# Altium schematic sheet 13-FPGA_Power.SchDoc(13-FPGA_Power)
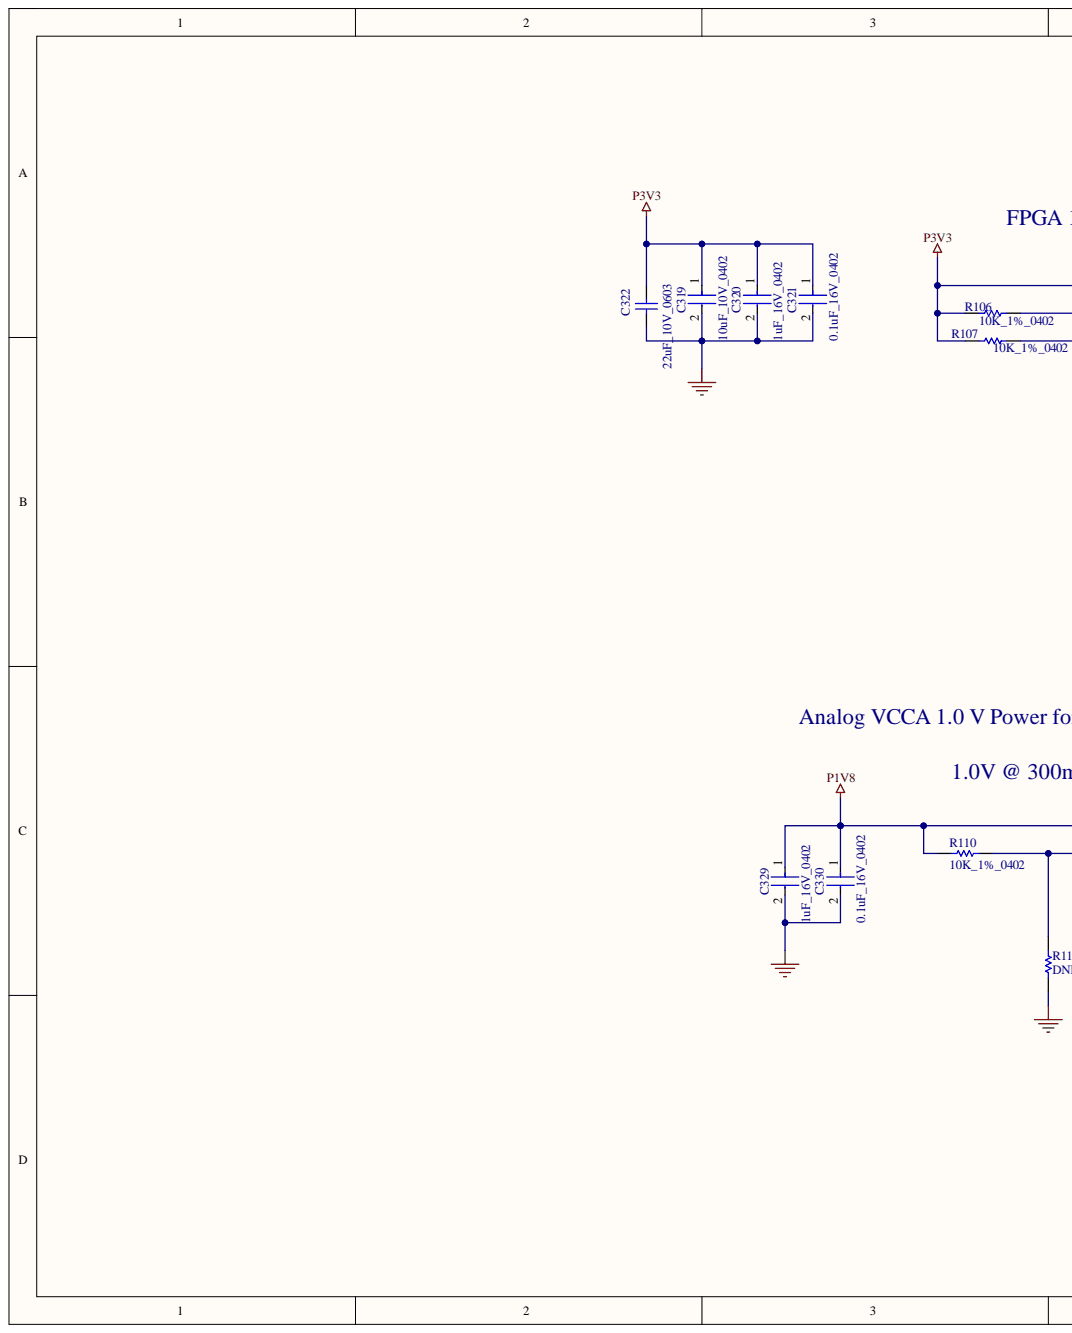
[diagram p0: 13-FPGA_Power.SchDoc, left]
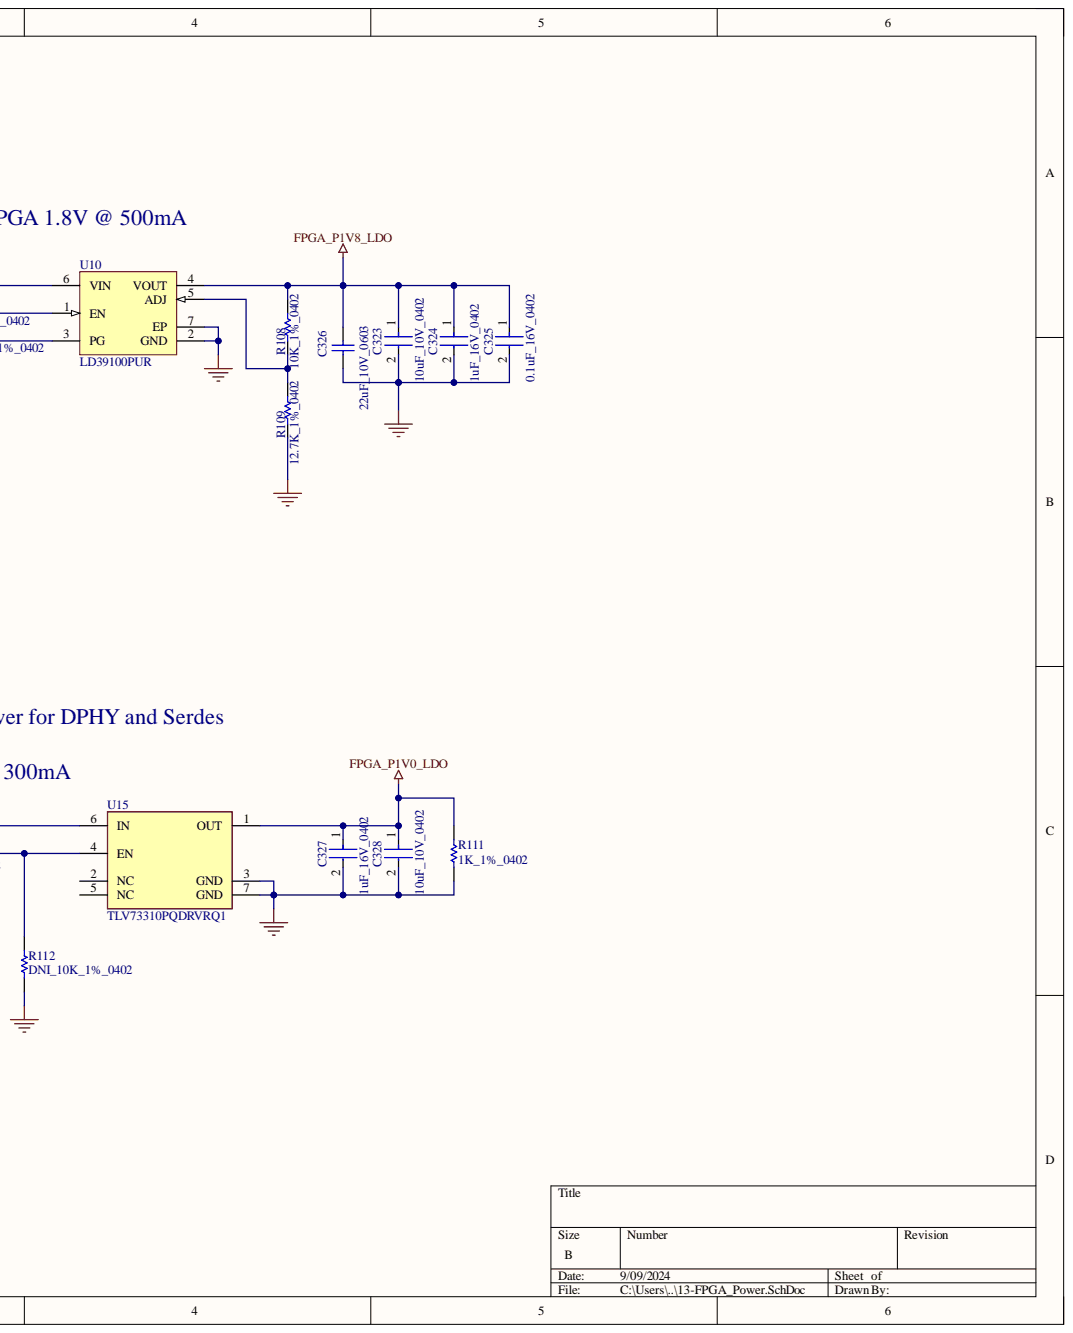
[diagram p1: 13-FPGA_Power.SchDoc, right]

## Parts
C319  10uF_10V_0402  [FP-CL05A106MP5NUNC-MFG]
C320  1uF_16V_0402  [FP-0402-L_1_0_0_05-W_0_5-IPC_A]
C321  0.1uF_16V_0402  [FP-C0402-BB-MFG]
C322  22uF_10V_0603  [CAPC1608X100X20ML10]
C323  10uF_10V_0402  [FP-CL05A106MP5NUNC-MFG]
C324  1uF_16V_0402  [FP-0402-L_1_0_0_05-W_0_5-IPC_A]
C325  0.1uF_16V_0402  [FP-C0402-BB-MFG]
C326  22uF_10V_0603  [CAPC1608X100X20ML10]
C327  1uF_16V_0402  [FP-0402-L_1_0_0_05-W_0_5-IPC_A]
C328  10uF_10V_0402  [FP-CL05A106MP5NUNC-MFG]
C329  1uF_16V_0402  [FP-0402-L_1_0_0_05-W_0_5-IPC_A]
C330  0.1uF_16V_0402  [FP-C0402-BB-MFG]
R106  10K_1%_0402  [RESC1005X40X25NL10T10]
R107  10K_1%_0402  [RESC1005X40X25NL10T10]
R108  10K_1%_0402  [RESC1005X40X25NL10T10]
R109  12.7K_1%_0402  [RESC1005X40X25NL05T05]
R110  10K_1%_0402  [RESC1005X40X25NL10T10]
R111  1K_1%_0402  [RESC1005X40X25LL05T10]
R112  DNI_10K_1%_0402  [RESC1005X40X25NL10T10]  (no BOM)
U10  LD39100PUR  [DFN6D_M]
U15  TLV73310PQDRVRQ1  Output Current: 300mA  [FP-DRV0006D-MFG]

## Nets
FPGA_P1V0_LDO: C327.1 C328.1 R111.2 U15.1(OUT)
FPGA_P1V8_LDO: C323.1 C324.1 C325.1 C326.2 R108.2 U10.4(VOUT)
GND: C319.2 C320.2 C321.2 C322.1 C323.2 C324.2 C325.2 C326.1 C327.2 C328.2 C329.2 C330.2 R109.1 R111.1 R112.1 U10.2(GND) U10.7(EP) U15.3(GND) U15.7(GND)
P1V8: C329.1 C330.1 R110.2 U15.6(IN)
P3V3: C319.1 C320.1 C321.1 C322.2 R106.2 R107.2 U10.6(VIN)
NetR106_1: R106.1 U10.1(EN)
NetR107_1: R107.1 U10.3(PG)
NetR108_1: R108.1 R109.2 U10.5(ADJ)
NetR110_1: R110.1 R112.2 U15.4(EN)

Not connected: U15 2(NC) 5(NC)

## Library data of the parts
C319 C323 C328 = CMP-13271-000002-1: CAP CER 10UF 10V X5R 0402; Manufacturer: Samsung; Manufacturer Part Number: CL05A106MP5NUNC; Material: Ceramic; Temperature Coefficient: 15%; Tolerance: 20%; Automotive: No; Capacitance: 10uF; Category: Cap; Component Kind: Standard; ComponentLink2Description: Datasheet; ComponentLink2URL: http://www.samsungsem.com/kr/support/product-search/mlcc/__icsFiles/afieldfile/2019/05/10/19-CL05A106MP5NUNC.pdf; Device Class L1: Passive Components; Device Class L2: Capacitors; Device Class L3: Ceramic Capacitors; Digikey Description: CAP CER 10UF 10V X5R 0402; Height: 0.7mm; Ipc Land Pattern Name: CAPC10050X50; Lead Free: Yes; Library Name: Altium Content Vault; Octopart: https://octopart.com/cl05a106mp5nunc-samsung-22457395; Package: CL05A106MP5NUNC; RoHS: TRUE; Temperature: -55°C to +85°C; Temperature Characteristic: X5R; Temperature Range High: +85°C; Temperature Range Low: -55°C; Value: 10uF; Voltage Rating: 10V
C320 C324 C327 C329 = CMP-14477-000104-2: None; Capacitance: 1uF; Dielectric: X5R; Tolerance: 10%; Voltage Rating: 16V; Case Code (Imperial): 0402; Case Code (Metric): 1005; Case/Package: 0402; Component Kind: Standard; Construction: Flat; Depth: 0.5mm; Halogen Free: Halogen Free; Height: 0.5mm; Length: 1mm; Library Name: Altium Content Vault; Max Operating Temperature: 85°C; Min Operating Temperature: -55°C; Mount: Surface Mount; Number of Pins: 2; Package Quantity: 1; Packaging: Tape and Reel; Radiation Hardening: No; RoHS Compliant: Yes; Series: M; Termination: SMD/SMT; Thickness: 0.022inch; Voltage Rating (DC): 16V; Width: 0.02inch
C321 C325 C330 = CMP-1034-02068-3: CAP CER 0.1UF 16V 5% X7R 0402; Manufacturer: Kemet; Manufacturer Part Number: C0402C104J4RACTU; Capacitance: 0.10 μF; Material: Ceramic; Temperature Coefficient: 15%; Tolerance: 0.05; Value: 0.10 μF; Automotive: No; Category: Cap; Component Kind: Standard; ComponentLink2Description: Datasheet; ComponentLink2URL: https://content.kemet.com/datasheets/KEM_C1002_X7R_SMD.pdf; Device Class L1: Passive Components; Device Class L2: Capacitors; Device Class L3: Ceramic Capacitors; Digikey Description: CAP CER 0.1UF 16V 5% X7R 0402; Height: 0.55mm; Ipc Land Pattern Name: CAPC10050X50; Lead Free: Yes; Library Name: Altium Content Vault; Mouser Description: CAP CER 0.1UF 16V X7R 0402; Octopart: https://octopart.com/c0402c104j4ractu-kemet-12573893; Package: C0402-BB; RoHS: TRUE; Temperature: -55°C to +125°C; Temperature Characteristic: X7R; Temperature Range High: +125°C; Temperature Range Low: -55°C; Voltage Rating: 16 V
C322 C326 = CMP-2000-05441-1: Chip Capacitor, 22 uF, +/- 20%, 10 V, -55 to 85 degC, 0603 (1608 Metric), RoHS, Tape and Reel; Manufacturer: TDK; PCN Part Number: MLCCPartNumberChange30/Nov/2012; Capacitance: 22µF; Design Resources: 20WNon-IsolatedBuckLEDDriverUsingPLLNK460KG; Kits: 445-9014-KIT-ND-KITMLCC100PF-22UF060310-50V; Online Catalog: CSeries,GeneralPurpose; Other Names: 445-9077-1; Product Training Modules: CSeriesGeneralApplicationsSEAT,CCV,andTVCLDesignToolsGuidetoReplacinganElectrolyticCapacitorwithanMLCC; Temperature Coefficient: X5R; Voltage - Rated: 10V; Applications: GeneralPurpose; Category: Capacitors; Component Kind: Standard; Datasheet URL: http://download.siliconexpert.com/pdfs/2015/4/21/15/54/45/779/tdk_/manual/37024850441143360mlcc_commercial_general_en.pdf; Datasheet Version: Mar-15; Family: CeramicCapacitors; Features: -; Height - Seated (Max): -; Lead Spacing: -; Lead Style: -; Library Name: Altium Content Vault; Manufacturer URL: http://www.tdk.com/; Mounting Type: SurfaceMount,MLCC; Operating Temperature: -55degC~85degC; Package / Case: 0603(1608Metric); Package Description: 2-Pin Surface Mount Device, Body 1.6 x 0.8 mm; Package Reference: 603; Packaging: CutTape(CT); Ratings: -; RoHS Information: RoHSCertificate-MLCC; Series: C; Size / Dimension: 0.063"Lx0.031"W(1.60mmx0.80mm); Standard Package: 1; Thickness (Max): 0.035"(0.90mm); Tolerance: ±20%
R106-R108 R110 R112 = CMP-2002-04694-1: Manufacturer: Bourns; Part Number: CR0402-FX-1002GLF; Library Name: Altium Content Vault
  R106-R108 R110: Component Kind: Standard
R109 = CMP-2002-08182-1: Manufacturer: Vishay Dale; Part Number: CRCW040212K7FKED; Component Kind: Standard; Library Name: Altium Content Vault
R111 = CMP-2002-03045-1: Manufacturer: Vishay Dale; Part Number: CRCW04021K00FKEDHP; Component Kind: Standard; Library Name: Altium Content Vault
U10 = CMP-0244-00287-1: 1 A Low Quiescent Current Low Noise Voltage Regulator, Adjustable from 0.8V, 6-Pin VFDFPN, Tape and Reel; Component Kind: Standard; ComponentLink1Description: Manufacturer URL; ComponentLink1URL: http://www.st.com; ComponentLink2Description: Datasheet; ComponentLink2URL: http://www.st.com/internet/com/TECHNICAL_RESOURCES/TECHNICAL_LITERATURE/DATASHEET/CD00233788.pdf; DatasheetVersion: 1/10/2011; Library Name: Altium Content Vault; PackageDescription: 6-Pin Plastic, Dual LLP, 3 x 3 mm Body, 0.95 mm Pitch, Exposed Pad; PackageReference: DFN6D; PackageVersion: 2008
U15 = CMP-04917-000366-1: IC REG LINEAR 1V 300MA 6WSON; Dropout Voltage: 125mV; Min Current Limit: 360mA; Number of Regulators: 1; Quiescent Current: 34uA; Component Kind: Standard; Lead Free: Lead Free; Library Name: Altium Content Vault; Max Operating Temperature: 125°C; Min Operating Temperature: -40°C; Mount: Surface Mount; Output Voltage: 1V; Packaging: Cut Tape; RoHS Compliant: Yes; Series: Automotive, AEC-Q100
